# Altium schematic sheet CANTRICK MCU.SchDoc("U_CANTRICK MCU")
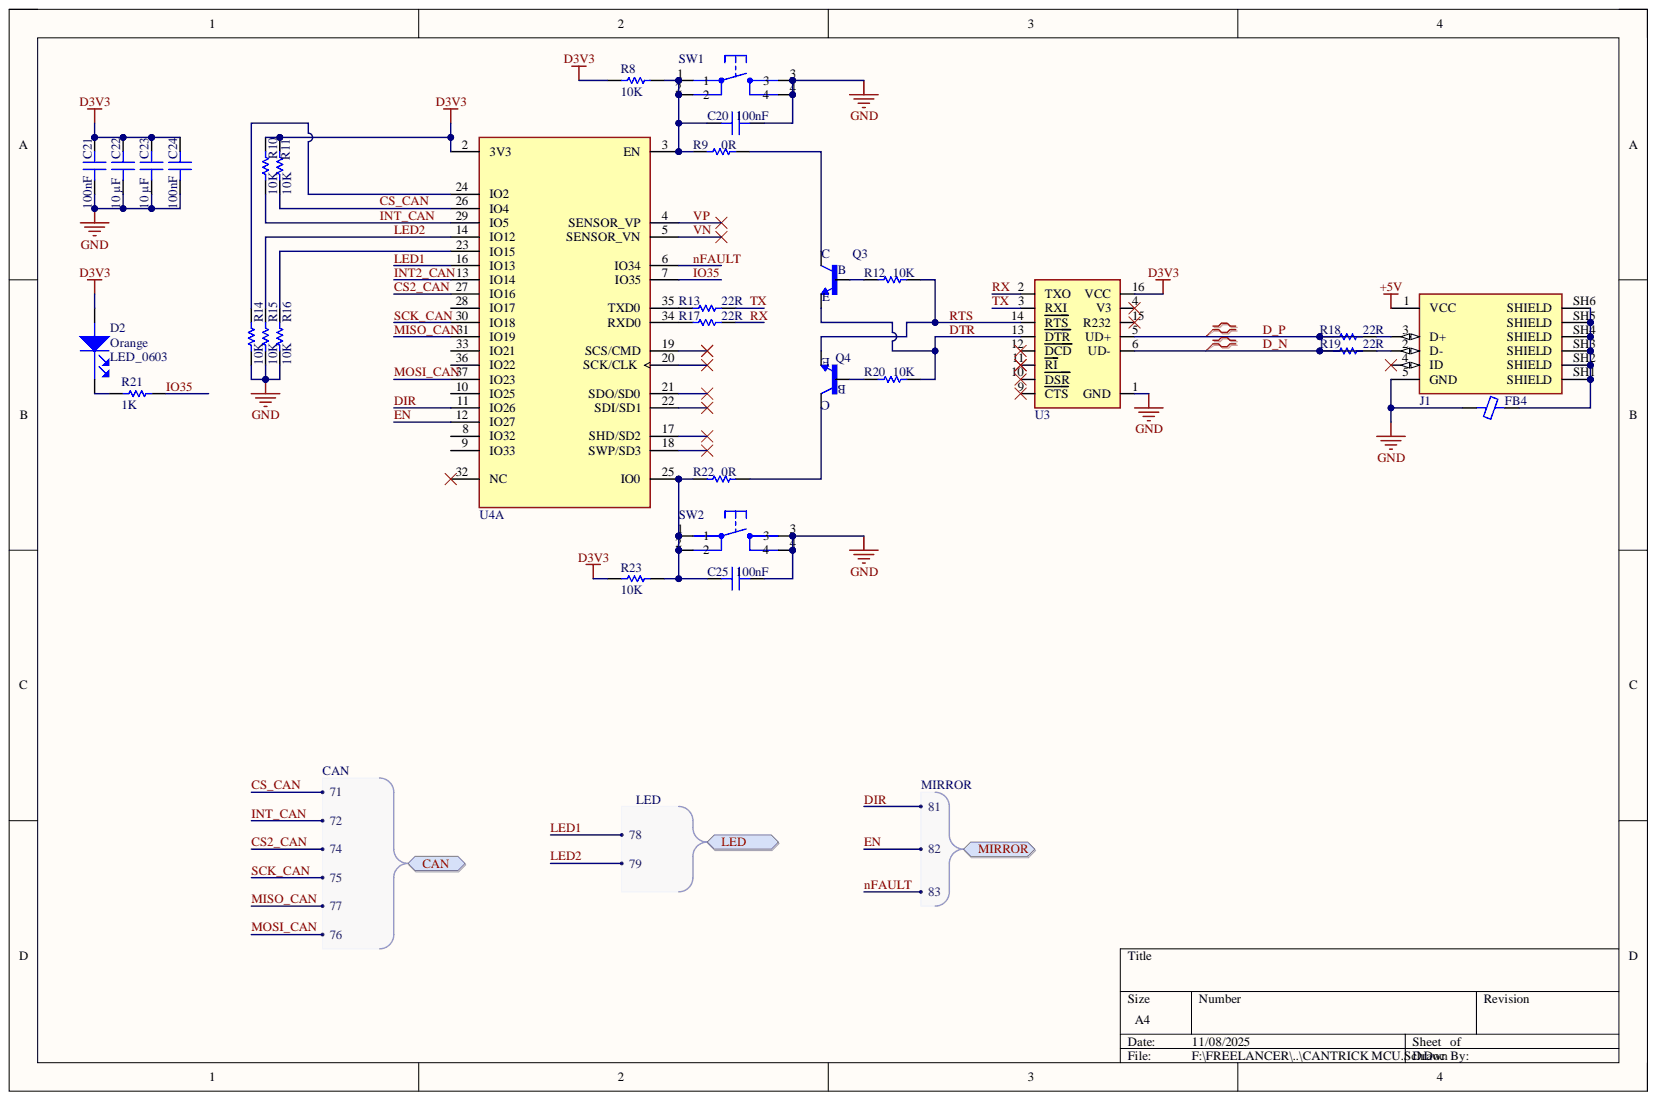
[diagram p0: CANTRICK MCU.SchDoc]

## Parts
C20  100nF  [CAP 0603]
C21  100nF  [CAP 0603]
C22  10 µF  [CAP 0603]
C23  10 µF  [CAP 0603]
C24  100nF  [CAP 0603]
C25  100nF  [CAP 0603]
D2  Orange  Package / Case: LED_0603  [LED]
FB4  330 Ohms @ 100 MHz  [FB_0603]  (value not drawn)
J1  MICRO B  [MICRO USB B]  (value not drawn)
Q3  NMOS  [SOT23-3]  (value not drawn)
Q4  NMOS  [SOT23-3]  (value not drawn)
R8  10K  [Res 0603]
R9  0R  [Res 0603]
R10  10K  [Res 0603]
R11  10K  [Res 0603]
R12  10K  [Res 0603]
R13  22R  [Res 0603]
R14  10K  [Res 0603]
R15  10K  [Res 0603]
R16  10K  [Res 0603]
R17  22R  [Res 0603]
R18  22R  [Res 0603]
R19  22R  [Res 0603]
R20  10K  [Res 0603]
R21  1K  [Res 0603]
R22  0R  [Res 0603]
R23  10K  [Res 0603]
SW1  SW2  [SWITCH]  (value not drawn)
SW2  SW2  [SWITCH]
U3  CH340C  [CH340C]  (value not drawn)
U4  ESP32-WROOM-32UE-N8  [ESP32S]  (value not drawn)  (part A)

## Ports
CAN, CAN.71, CAN.72, CAN.74, CAN.75, CAN.76, CAN.77, LED, LED.78, LED.79, MIRROR, MIRROR.81, MIRROR.82, MIRROR.83

## Nets
+5V: J1.1(VCC)
D3V3: C21.2 C22.2 C23.2 C24.2 D2.1 R8.1 R10.2 R11.2 R23.1 U3.16(VCC) U4.2(3V3)
GND: C20.1 C21.1 C22.1 C23.1 C24.1 C25.1 FB4.1 J1.5(GND) R14.1 R15.1 R16.1 SW1.3 SW1.4 SW2.3 SW2.4 U3.1(GND)
CS2_CAN: U4.27(IO16) ; port CAN
CS_CAN: R11.1 U4.26(IO4) ; port CAN
D_N: R19.1 U3.6(UD-)
D_P: R18.1 U3.5(UD+)
DIR: U4.11(IO26) ; port MIRROR
DTR: Q3.2 R20.2 U3.13(DTR)
EN: U4.12(IO27) ; port MIRROR
INT2_CAN: U4.13(IO14)
INT_CAN: R10.1 U4.29(IO5) ; port CAN
IO35: R21.2 U4.7(IO35)
LED1: U4.16(IO13) ; port LED
LED2: R15.2 U4.14(IO12) ; port LED
MISO_CAN: U4.31(IO19) ; port CAN
MOSI_CAN: U4.37(IO23) ; port CAN
nFAULT: U4.6(IO34) ; port MIRROR
RTS: Q4.2 R12.2 U3.14(RTS)
RX: R17.2 U3.2(TXO)
SCK_CAN: U4.30(IO18) ; port CAN
TX: R13.2 U3.3(RXI)
VN: U4.5(SENSOR_VN)
VP: U4.4(SENSOR_VP)
NetC20_2: C20.2 R8.2 R9.1 SW1.1 SW1.2 U4.3(EN)
NetC25_2: C25.2 R22.1 R23.2 SW2.1 SW2.2 U4.25(IO0)
NetD2_2: D2.2 R21.1
NetFB4_2: FB4.2 J1.SH1(SHIELD) J1.SH2(SHIELD) J1.SH3(SHIELD) J1.SH4(SHIELD) J1.SH5(SHIELD) J1.SH6(SHIELD)
NetJ1_2: J1.2(D-) R19.2
NetJ1_3: J1.3(D+) R18.2
NetQ3_1: Q3.1 R12.1
NetQ3_3: Q3.3 R9.2
NetQ4_1: Q4.1 R20.1
NetQ4_3: Q4.3 R22.2
NetR13_1: R13.1 U4.35(TXD0)
NetR14_2: R14.2 U4.24(IO2)
NetR16_2: R16.2 U4.23(IO15)
NetR17_1: R17.1 U4.34(RXD0)

Not connected: J1 4(ID); U3 4(V3) 9(CTS) 10(DSR) 11(RI) 12(DCD) 15(R232); U4 8(IO32) 9(IO33) 10(IO25) 17(SHD/SD2) 18(SWP/SD3) 19(SCS/CMD) 20(SCK/CLK) 21(SDO/SD0) 22(SDI/SD1) 28(IO17) 32(NC) 33(IO21) 36(IO22)

## Library data of the parts
C20 C21 C24 C25 = GMC10X7R106K10NT: CAP0603 X7R 10UF 10% 10V; manufacturer: Cal-Chip Electronics, Inc.; Capacitance: 10 µF; Database Table Name: Capacitors - Ceramic - 0603; Applications: General Purpose; Component Kind: Standard; componentlink1description: Datasheet; componentlink1url: https://88ce57.p3cdn1.secureserver.net/wp-content/uploads/2023/01/gmc_series-2.pdf; componentlink2description: DigiKey Link; componentlink2url: https://www.digikey.com/en/products/detail/cal-chip-electronics-inc/GMC10X7R106K10NT/18151126; Device Package: 0603 (1608 Metric); lastupdated: 2/9/2024 8:09:39 PM; Library Name: Celestial Altium Library - altium_library.DbLib; Lifecycle Status: Active; Minimum Order: 1; Mounting Type: Surface Mount, MLCC; Operating Temperature: -55°C ~ 125°C; Package / Case: 0603 (1608 Metric); packaging: TapeAndReel; Part Number: GMC10X7R106K10NT; Part Status: Active; partid: 49835; price: 0.26; series: GMC; Size / Dimension: 0.063" L x 0.031" W (1.60mm x 0.80mm); Supplier 1: DigiKey; Supplier Part Number 1: 4713-GMC10X7R106K10NTCT-ND; Temperature Coefficient: X7R; Thickness (Max): 0.039" (1.00mm); Tolerance: ±10%; Value: 100nF; Voltage - Rated: 10V
C22 C23 = GMC10X7R106K10NT: CAP0603 X7R 10UF 10% 10V; manufacturer: Cal-Chip Electronics, Inc.; Database Table Name: Capacitors - Ceramic - 0603; Applications: General Purpose; Capacitance: 10 µF; Component Kind: Standard; componentlink1description: Datasheet; componentlink1url: https://88ce57.p3cdn1.secureserver.net/wp-content/uploads/2023/01/gmc_series-2.pdf; componentlink2description: DigiKey Link; componentlink2url: https://www.digikey.com/en/products/detail/cal-chip-electronics-inc/GMC10X7R106K10NT/18151126; Device Package: 0603 (1608 Metric); lastupdated: 2/9/2024 8:09:39 PM; Library Name: Celestial Altium Library - altium_library.DbLib; Lifecycle Status: Active; Minimum Order: 1; Mounting Type: Surface Mount, MLCC; Operating Temperature: -55°C ~ 125°C; Package / Case: 0603 (1608 Metric); packaging: TapeAndReel; Part Number: GMC10X7R106K10NT; Part Status: Active; partid: 49835; price: 0.26; series: GMC; Size / Dimension: 0.063" L x 0.031" W (1.60mm x 0.80mm); Supplier 1: DigiKey; Supplier Part Number 1: 4713-GMC10X7R106K10NTCT-ND; Temperature Coefficient: X7R; Thickness (Max): 0.039" (1.00mm); Tolerance: ±10%; Value: 10 µF; Voltage - Rated: 10V
D2 = LED ORANGE 606NM 0603: LED SMARTLED ORANGE 606NM 0603; Manufacturer: OSRAM Opto Semiconductors Inc; Manufacturer Part Number: LO L29K-H2K1-24-Z; By: Hieu.Nguyen_20191030; Current - Test: 2mA; Lens Style/Size: Rectangle with Flat Top, 1.3mm x 0.8mm; Lens Type: Diffused; Luminous Flux @ Current - Test: 25 mlm; Millicandela Rating: 6.3mcd; Viewing Angle: 160°; Voltage - Forward (Vf) Typ: 1.8V; Wavelength - Peak: 610nm; Category: Optoelectronics; Color: Orange; Component Kind: Standard; ComponentLink1Description: http://catalog.osram-os.com/catalogue/catalogue.do;jsessionid=302CF83AF637AE24E40AEB0ADA267EDD?act=downloadFile&favOid=020000010003f9de000100b6; ComponentLink1URL: http://catalog.osram-os.com/catalogue/catalogue.do;jsessionid=302CF83AF637AE24E40AEB0ADA267EDD?act=downloadFile&favOid=020000010003f9de000100b6; Height: 0.65mm; Library Name: Stm32_Flyback_Driver_250814_1.SCHLIB; Mounting Type: Surface Mount; Packaging: Cut Tape (CT); Pricing 1: 1=0.17, 10=0.141, 100=0.1127, 250=0.0986, 500=0.09016 (USD); RoHS: RoHS Compliant; Size / Dimension: 1.70mm L x 0.80mm W; Stock 1: 15900; Supplier 1: Digi-Key; Supplier Part Number 1: 475-2740-1-ND; Wavelength - Dominant: 606nm
FB4 = BLM18KG331SH1D: FERRITE BEAD 330 OHM 0603 1LN; Manufacturer: Murata Electronics; Current Rating (Max): 1.7A; Database Table Name: Filters - Ferrite Beads and Chips; DC Resistance (DCR) (Max): 80mOhm; Filter Type: Power Line; Number of Lines: 1; Component Kind: Standard; ComponentLink1Description: Datasheet; ComponentLink1URL: https://www.murata.com/en-global/products/productdata/8796737273886/QNFA9101.pdf; ComponentLink2Description: DigiKey Link; ComponentLink2URL: https://www.digikey.com/en/products/detail/murata-electronics/BLM18KG331SH1D/5881246; Device Package: 0603 (1608 Metric); Height (Max): 0.037" (0.95mm); Impedance @ Frequency: 330 Ohms @ 100 MHz; LastUpdated: 3/11/2024 7:43:28 AM; Library Name: Celestial Altium Library - altium_library.DbLib; Lifecycle Status: Active; Minimum Order: 1; Mounting Type: Surface Mount; Operating Temperature: -55°C ~ 125°C; Package / Case: 0603 (1608 Metric); Packaging: TapeAndReel; Part Number: BLM18KG331SH1D; Part Status: Active; PartId: 932; Price: 0.02; Ratings: AEC-Q200; Series: EMIFIL®, BLM18; Size / Dimension: 0.063" L x 0.032" W (1.60mm x 0.80mm); Supplier 1: DigiKey; Supplier Part Number 1: 490-13257-1-ND; Value: 330 Ohms @ 100 MHz
J1 = MICRO USB B: Component Kind: Standard; Library Name: ESP32-S.SchLib
Q3 Q4 = MMBT3904: MMBT3904 Series 40 V CE Breakdown 0.2 A NPN General Purpose Amplifier - SOT-23; Manufacturer: onsemi; Collector Base Voltage (VCBO): 60V; Collector Emitter Breakdown Voltage: 40V; Collector Emitter Saturation Voltage: 300mV; Current Rating: 200mA; Emitter Base Voltage (VEBO): 6V; hFE Min: 100; Max Power Dissipation: 350mW; Transition Frequency: 300MHz; Case/Package: SOT-23; Category: BJTs; Collector Emitter Voltage (VCEO): 40V; Collector-emitter Voltage-Max: 300mV; Component Kind: Standard; Lead Free: Lead Free; Library Name: Stm32_Flyback_Driver_250814_1.SCHLIB; Manufacturer Part Number: MMBT3904; Max Breakdown Voltage: 40V; Max Collector Current: 200mA; Max Operating Temperature: 150°C; Min Operating Temperature: -55°C; Mount: Surface Mount; Number of Pins: 3; Package Quantity: 1; Packaging: Tape and Reel; Polarity: NPN; Pricing 1: 5=0,136, 25=0,133, 100=0,0476, 250=0,0435, 500=0,043, 1000=0,022, 5000=0,02 (GBP); Radiation Hardening: No; Reach SVHC Compliant: No; RoHS: Yes; RoHS Compliant: Yes; Series: -; Supplier 1: Farnell; Supplier Part Number 1: 9846727; Termination: SMD/SMT; Voltage Rating (DC): 40V; Weight: 0.03g
R8-R23 = Resistor 2.2K: Res Thick Film 0603 2.2K Ohm 5% 1/10W ±200ppm/°C Molded SMD SMD Paper T/R; Manufacturer: Vishay; Manufacturer Part Number: CRCW06032K20JNEA; Max Power Dissipation: 100mW; Resistance: 2.2kR; Voltage Rating: 75V; Case Code (Imperial): 0603; Case Code (Metric): 1608; Case/Package: 0603; Category: Chip SMD Resistors; Component Kind: Standard; ComponentLink1Description: https://datasheet.ciiva.com/2462/dcrcwe3-2462048.pdf?src-supplier=Digikey; ComponentLink1URL: https://datasheet.ciiva.com/2462/dcrcwe3-2462048.pdf?src-supplier=Digikey; ComponentLink2Description: https://datasheet.ciiva.com/16767/70201119-16767464.pdf?src-supplier=Allied+Electronics; ComponentLink2URL: https://datasheet.ciiva.com/16767/70201119-16767464.pdf?src-supplier=Allied+Electronics; ComponentLink3Description: https://datasheet.ciiva.com/2462/dcrcwe3-2462046.pdf?src-supplier=Digikey; ComponentLink3URL: https://datasheet.ciiva.com/2462/dcrcwe3-2462046.pdf?src-supplier=Digikey; ComponentLink4Description: https://datasheet.ciiva.com/5541/dcrcwe3-109170-5541959.pdf?src-supplier=Mouser; ComponentLink4URL: https://datasheet.ciiva.com/5541/dcrcwe3-109170-5541959.pdf?src-supplier=Mouser; ComponentLink5Description: https://datasheet.ciiva.com/16286/dcrcwe3-16286997.pdf?src-supplier=Future+Electronics; ComponentLink5URL: https://datasheet.ciiva.com/16286/dcrcwe3-16286997.pdf?src-supplier=Future+Electronics; Construction: Rectangular; Contact Plating: Tin; Depth: 0.85mm; Features: Automotive AEC-Q200; Height: 0.45mm; Lead Free: 5697LeadFree; Length: 1.55mm; Library Name: Stm32_Flyback_Driver_250814_1.SCHLIB; Max Operating Temperature: 155°C; Military Standard: Not; Min Operating Temperature: -55°C; Number of Terminations: 2; Package Quantity: 5000; Packaging: Tape and Reel; Power Rating: 100mW; Pricing 1: 5000=0.0053 (USD); Radiation Hardening: No; Ratings: AEC-Q200; Reach SVHC Compliant: Unknown; Resistance Tolerance: 5%; Resistor Type: Thick Film; RoHS: Yes; RoHS Compliant: Yes; Series: CRCW; Size Code: 0603; Stock 1: 130000; Supplier 1: Arrow Electronics; Supplier Part Number 1: CRCW06032K20JNEA; Temperature Coefficient: 200ppm/°C; Termination: SMD/SMT; Tolerance: 5%; Weight: 7.1E-05oz; Width: 0.85mm
SW1 SW2 = SW2: Switch button 4 pin; Component Kind: Standard; Library Name: Stm32_Flyback_Driver_250814_1.SCHLIB
U4 = ESP32-WROOM-32UE-N8: Multiprotocol Modules SMD module ESP32-WROOM-32UE, ESP32-D0WD-V3, ESP32 ECO V3,; Manufacturer: Espressif Systems; Category: LDO; Component Kind: Standard; ComponentLink1Description: https://datasheet.octopart.com/ESP32-WROOM-32UE-N8-Espressif-Systems-datasheet-188867343.pdf?src-supplier=TME; ComponentLink1URL: https://datasheet.octopart.com/ESP32-WROOM-32UE-N8-Espressif-Systems-datasheet-188867343.pdf?src-supplier=TME; Library Name: ESP32-S.SchLib; Manufacturer Part Number: ESP32-WROOM-32UE-N8; Pricing 1: 1=5,28, 10=4,566, 25=4,3196, 100=3,9802, 250=3,7768 (USD); RoHS: No; Stock 1: 3266; Supplier 1: Digikey; Supplier Part Number 1: 1965-ESP32-WROOM-32UE-N8CT-ND
U3 = CH340C: Component Kind: Standard; Library Name: ESP32-S.SchLib
